# Altium schematic sheet battboard.SchDoc(battboard)
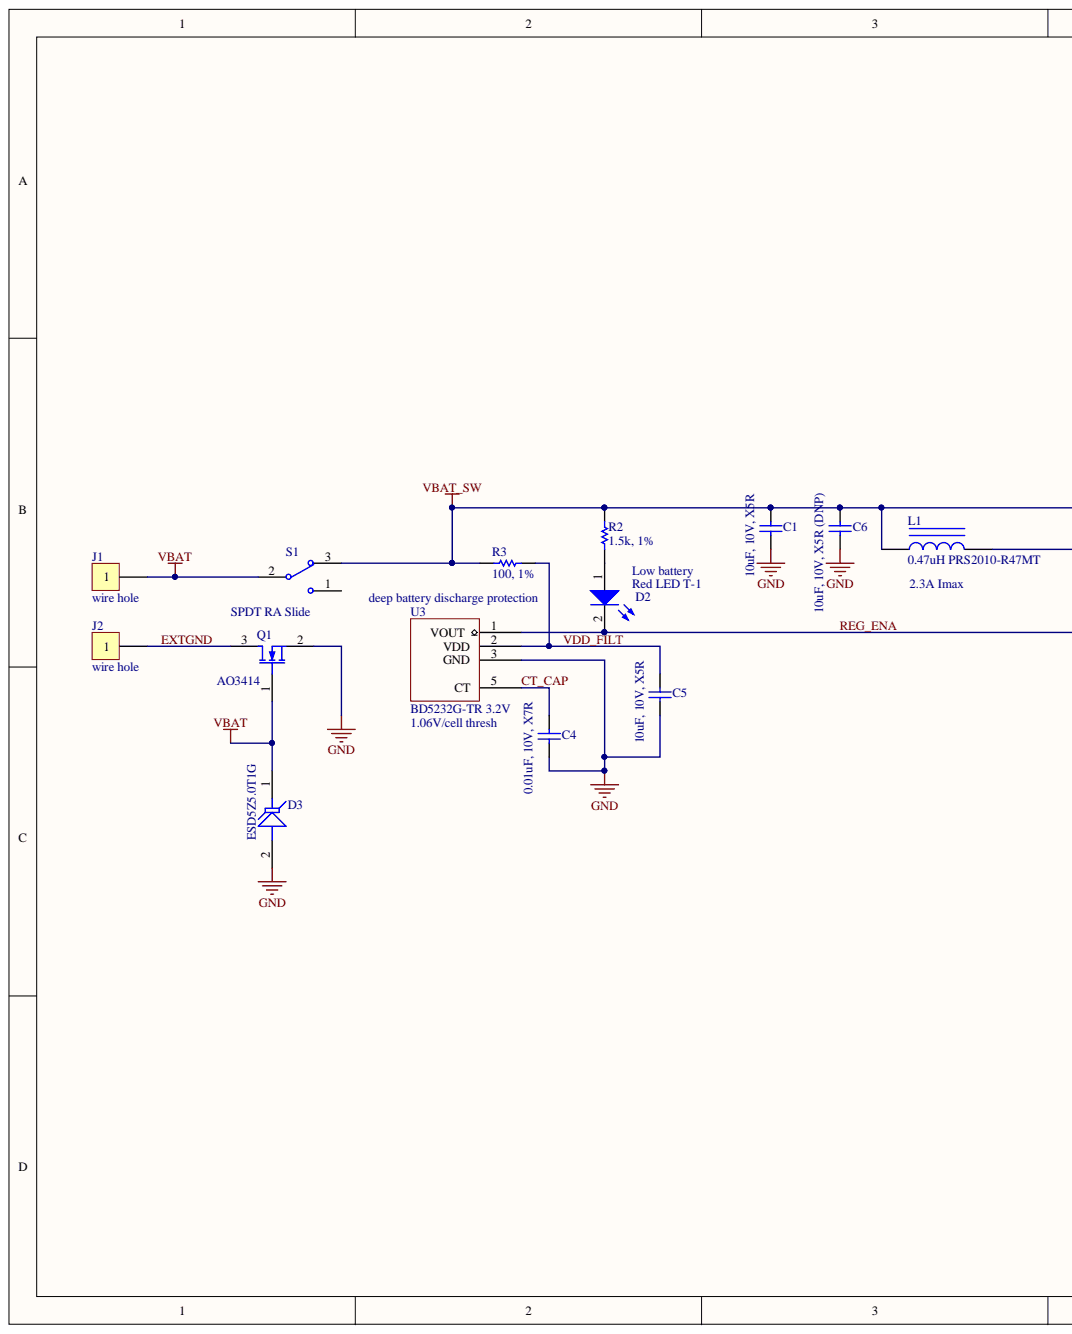
[diagram p0: battboard.SchDoc, left]
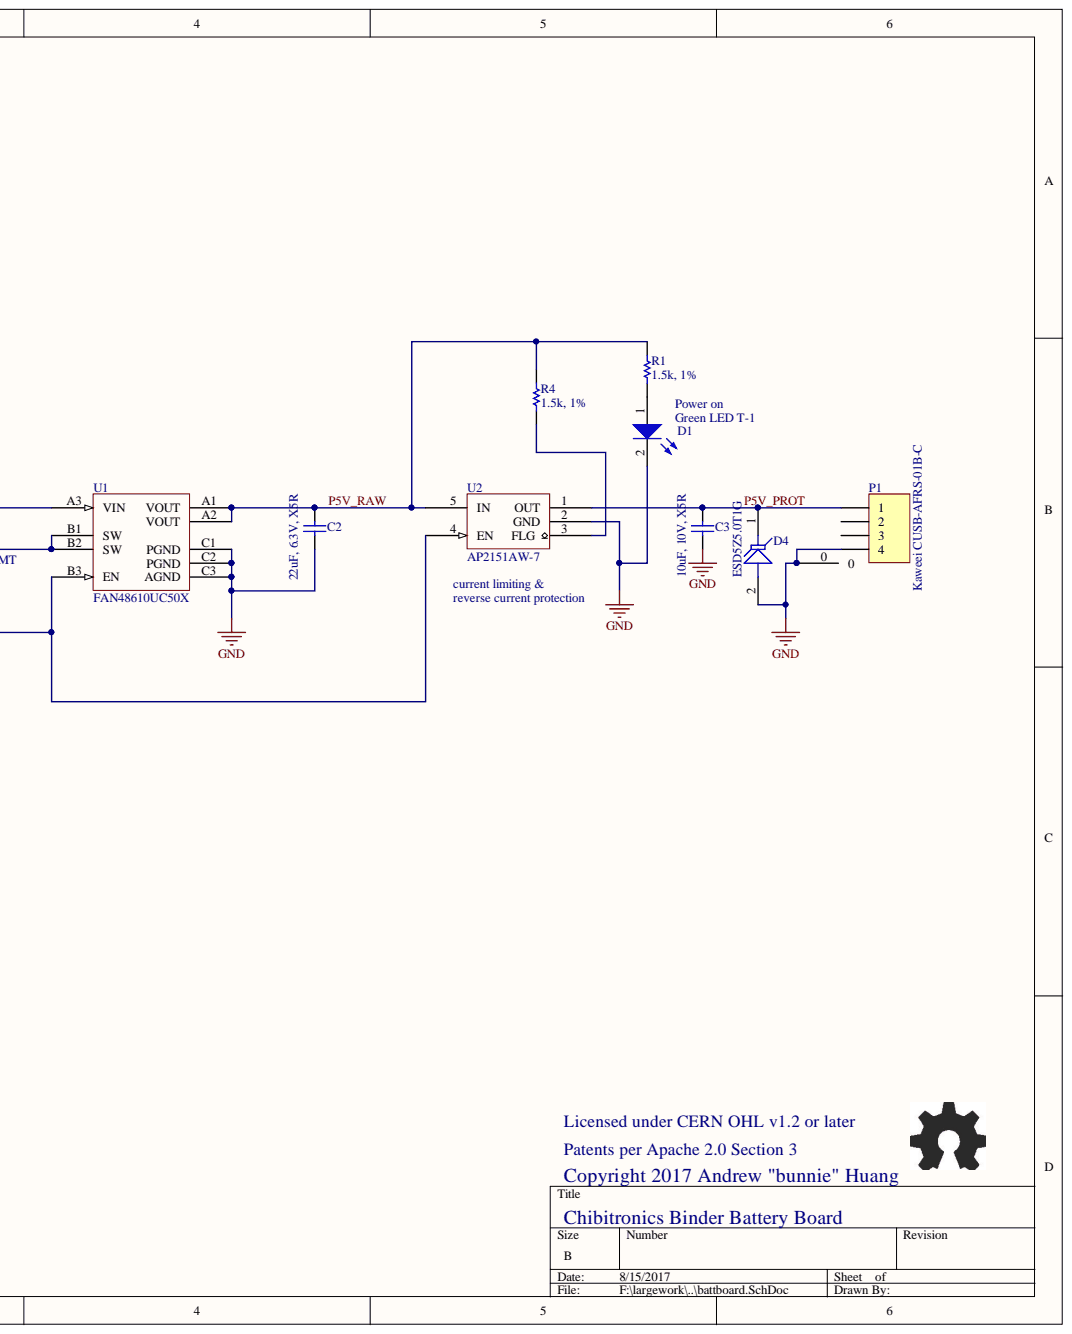
[diagram p1: battboard.SchDoc, right]

## Parts
C1  10uF, 10V, X5R  [CAPC2012N]
C2  22uF, 6.3V, X5R  [CAPC2012N]
C3  10uF, 10V, X5R  [CAPC2012N]
C4  0.01uF, 10V, X7R  [CAPC1005N]
C5  10uF, 10V, X5R  [CAPC2012N]
C6  10uF, 10V, X5R (DNP)  [CAPC2012N]
D1  Green LED T-1  [LED-T1-3MM]
D2  Red LED T-1  [LED-T1-3MM]
D3  ESD5Z5.0T1G  [SOD-523]
D4  ESD5Z5.0T1G  [SOD-523]
J1  wire hole  [TP_HOLE]
J2  wire hole  [TP_HOLE]
L1  0.47uH PRS2010-R47MT  [INDC2016X12N]
P1  Kaweei CUSB-AFRS-01B-C  VENDOR: Kaweei  MPN: CUSB-AFRS-01B-C  [USB_TYPEA_TH_SINGLE]
Q1  AO3414  [SOT23-3N]
R1  1.5k, 1%  [RESC1005N]
R2  1.5k, 1%  [RESC1005N]
R3  100, 1%  [RESC1005N]
R4  1.5k, 1%  [RESC1005N]
S1  SPDT RA Slide  [CK_OS102011MA1QN1C]
U1  FAN48610UC50X  [BGA9C40P3X3_122X122X62]
U2  AP2151AW-7  [SOT23-5AN]
U3  BD5232G-TR 3.2V  MPN: BD5232G-TR  [SOT23-5AN]

## Nets
GND: C1.2 C2.2 C3.2 C4.2 C5.2 C6.2 D1.2 D3.2 D4.2 P1.0 P1.4 Q1.2 U1.C1(PGND) U1.C2(PGND) U1.C3(AGND) U2.2(GND) U3.3(GND)
VBAT: D3.1 J1.1 Q1.1 S1.2
VBAT_SW: C1.1 C6.1 L1.1 R2.1 R3.1 S1.3 U1.A3(VIN)
CT_CAP: C4.1 U3.5(CT)
EXTGND: J2.1 Q1.3
P5V_PROT: C3.1 D4.1 P1.1 U2.1(OUT)
P5V_RAW: C2.1 R1.1 R4.1 U1.A1(VOUT) U1.A2(VOUT) U2.5(IN)
REG_ENA: D2.2 U1.B3(EN) U2.4(EN) U3.1(VOUT)
VDD_FILT: C5.1 R3.2 U3.2(VDD)
NetD1_1: D1.1 R1.2
NetD2_1: D2.1 R2.2
NetL1_2: L1.2 U1.B1(SW) U1.B2(SW)
NetR4_2: R4.2 U2.3(FLG)

Not connected: P1 2 3; S1 1

## Library data of the parts
C1 C3 C5 = Cap Semi: Capacitor (Semiconductor SIM Model); MPN: CL21A106KPCLQNC; VENDOR: Samsung; MYPN: C-106-10-X5R-0805; Simulation: CAP; Signal Integrity: Cap Semi; Library Name: Miscellaneous Devices.IntLib; Component Kind: Standard
C2 = Cap Semi: Capacitor (Semiconductor SIM Model); MPN: CL21A226KQCLRNC; VENDOR: Samsung; MYPN: C-226-6p3-X5R-0805; Simulation: CAP; Signal Integrity: Cap Semi; Library Name: Miscellaneous Devices.IntLib; Component Kind: Standard
C4 = Cap Semi: Capacitor (Semiconductor SIM Model); MPN: CL05B103KP5NNNC; VENDOR: Samsung; MYPN: C-103-10-X7R-0402; Simulation: CAP; Signal Integrity: Cap Semi; Library Name: Miscellaneous Devices.IntLib; Component Kind: Standard
C6 = Cap Semi: Capacitor (Semiconductor SIM Model); VENDOR: Samsung; MYPN: C-106-10-X5R-0805-DNP; Simulation: CAP; Signal Integrity: Cap Semi; MPN: DNP; Library Name: Miscellaneous Devices.IntLib; Component Kind: Standard
D1 = LED2: White LED; MPN: CB-204QGC; VENDOR: Citybright; MYPN: D-LED-GREEN-T1-CLEAR; Simulation: LED2; Published: 8-Jun-2000; PackageVersion: Feb-2002; PackageReference: 3.2X1.6X1.1; Library Name: Miscellaneous Devices.IntLib; LatestRevisionNote: Re-released for DXP Platform.; LatestRevisionDate: 17-Jul-2002; Component Kind: Standard; Publisher: Altium Limited
D2 = LED2: White LED; MPN: CB-204QRC; VENDOR: Citybright; MYPN: D-LED-RED-T1-CLEAR; Simulation: LED2; Published: 8-Jun-2000; PackageVersion: Feb-2002; PackageReference: 3.2X1.6X1.1; Library Name: Miscellaneous Devices.IntLib; LatestRevisionNote: Re-released for DXP Platform.; LatestRevisionDate: 17-Jul-2002; Component Kind: Standard; Publisher: Altium Limited
D3 D4 = Tranzorb: On Semi 5.0V standoff TVS diode; VENDOR: On Semiconductor; MYPN: D-ESD5Z5.0T1G; Published: 24-Mar-1999; PackageReference: DO-7; MPN: ESD5Z5.0T1G; Library Name: Miscellaneous Devices.IntLib; LatestRevisionNote: Re-released for DXP Platform.; LatestRevisionDate: 17-Jul-2002; Component Kind: Standard; Publisher: Altium Limited
J1 J2 = CON1: Connector; VENDOR: DNP; MYPN: J-TP-HOLE1; MPN: DNP; Component Kind: Standard
L1 = Inductor Iron: Magnetic-Core Inductor; MPN: PRS2010-4R7MT; VENDOR: Fenghua; MYPN: L-PRS2010-4R7MT; Simulation: INDUCTOR; Signal Integrity: Inductor Iron; Library Name: Miscellaneous Devices.IntLib; Component Kind: Standard
P1 = Header 4: Header, 4-Pin; MYPN: J-USBA-F-TH; Signal Integrity: Connector; Library Name: Miscellaneous Connectors.IntLib; LatestRevisionNote: Re-released for DXP Platform.; LatestRevisionDate: 17-Jul-2002; Component Kind: Standard; Publisher: Altium Limited
Q1 = MOSFET-N: N-Channel MOSFET; VENDOR: Alpha & Omega Semiconductor; MYPN: Q-AO3414; Simulation: NMOS; Published: 8-Jun-2000; PackageVersion: 1995/1996; PackageReference: E3; MPN: AO3414; Library Name: Miscellaneous Devices.IntLib; LatestRevisionNote: Re-released for DXP Platform.; LatestRevisionDate: 17-Jul-2002; Component Kind: Standard; Publisher: Altium Limited
R1 R2 R4 = Res1: Resistor; MPN: RS02U152FT; VENDOR: Fenghua; MYPN: R-152-1P-1_16W-0402; Simulation: RESISTOR; Signal Integrity: Res; Library Name: Miscellaneous Devices.IntLib; Component Kind: Standard
R3 = Res1: Resistor; MPN: RS02U101FT; VENDOR: Fenghua; MYPN: R-101-1P-1_16W-0402; Simulation: RESISTOR; Signal Integrity: Res; Library Name: Miscellaneous Devices.IntLib; Component Kind: Standard
S1 = SW-SPDT: SPDT Subminiature slide switch, RA mount; MPN: SK-12D02VG4; VENDOR: Haoyu; MYPN: SW-8.6x4.3-SPDT-SLIDE-RA; Published: 24-Mar-1999; PackageReference: TL36WW15050; PackageDescription: Switch; 4 Leads; Library Name: Miscellaneous Devices.IntLib; LatestRevisionNote: Schematic revised, PCB Footprint replaced.; LatestRevisionDate: 17-Dec-2003; DatasheetVersion: 05-Dec-2000; ComponentLink1URL: http://www.apem.com; ComponentLink1Description: Manufacturer Link; Component Kind: Standard; Publisher: Altium Limited
U1 = FAN48610UC50X: 2.5MHz, fixed-output sync TinyBoost(R) regulator; VENDOR: Fairchild Semiconductor; MYPN: U-FAN48610UC50X; MPN: FAN48610UC50X; Library Name: commonsch-new.SchLib; Component Kind: Standard
U2 = AP2151WG-7: 0.5A single channel current-limited power switch; VENDOR: Diodes Incorporated; MYPN: U-AP2151AW-7; MPN: AP2151AW-7; Library Name: commonsch-new.SchLib; Component Kind: Standard
U3 = BD5230G-TR: CMOS Voltage Detector IC, 3.2V, reset to low; VENDOR: Rohm; MYPN: U-BD5232G-TR; Library Name: commonsch-new.SchLib; Component Kind: Standard
